# Altium schematic sheet Arduino Connectors.SchDoc("Arduino Connectors")
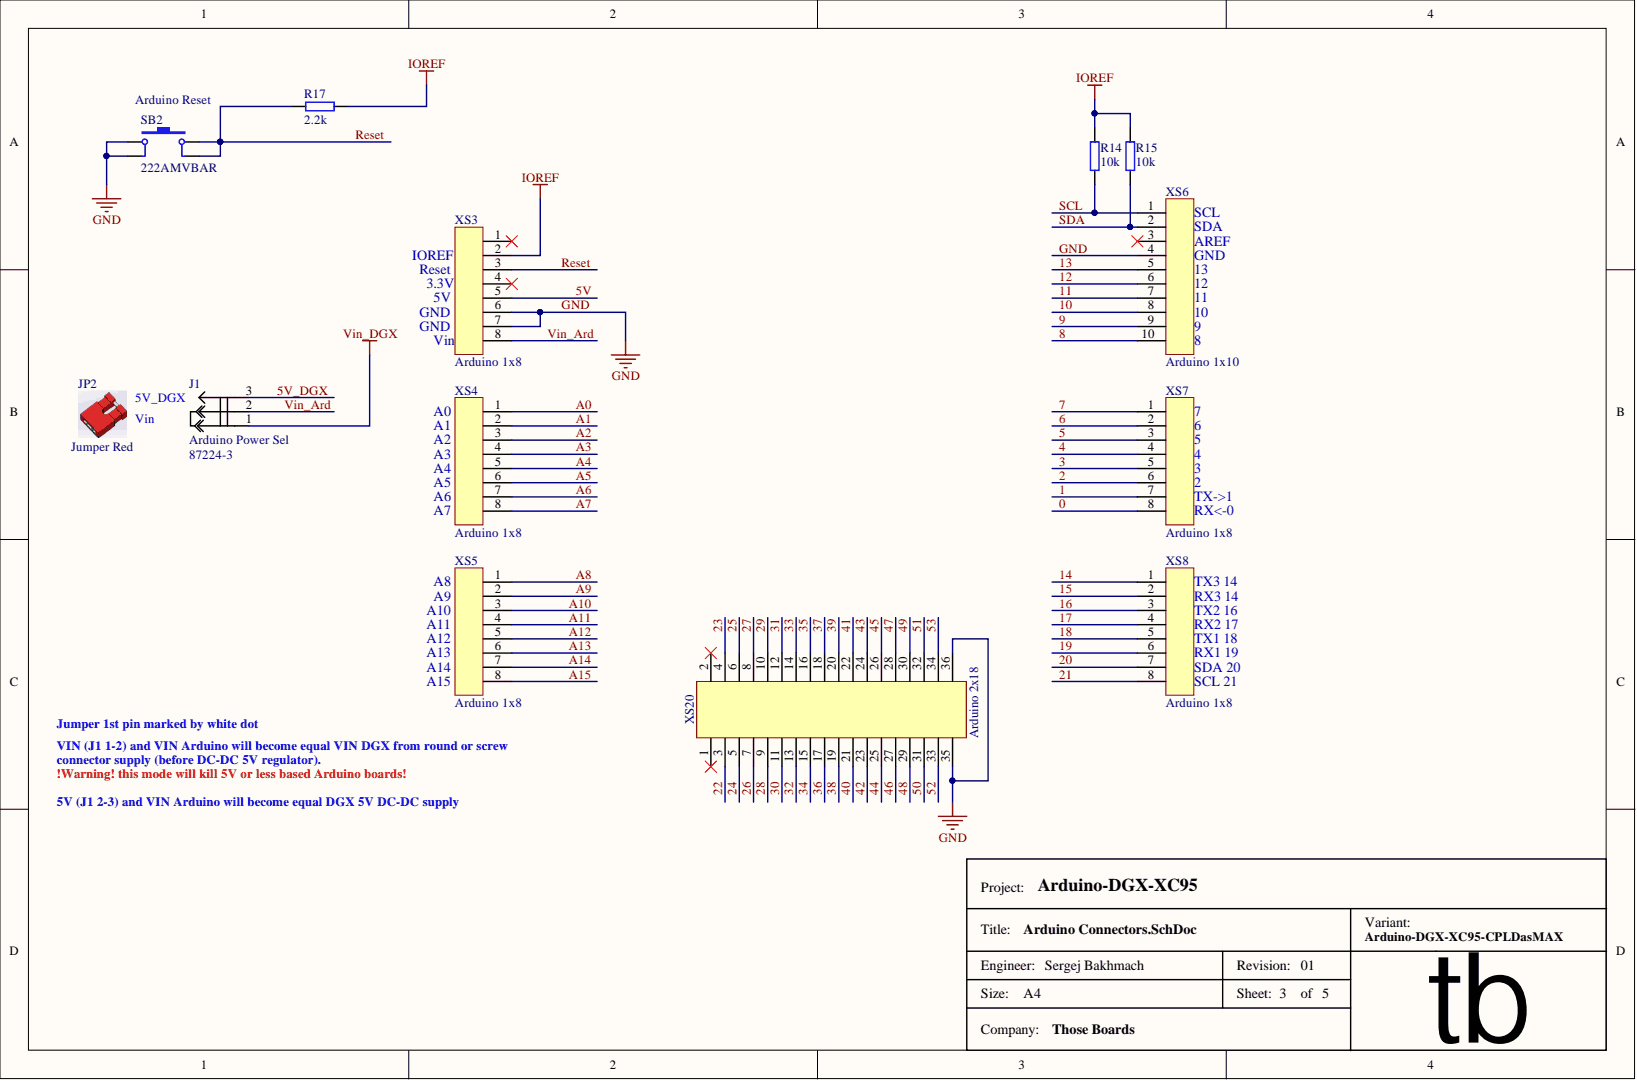
[diagram p0: Arduino Connectors.SchDoc]

## Parts
J1  Arduino Power Sel  value 87224-3  Manufacturer Part: 87224-3  [Jumper_3pin_red]
JP2  value Jumper Red  Supplier Part: Jumper  (hidden comment: VCC_sel)  (mechanical)
R14  value 10k  [0603_RESISTOR]  (hidden comment: Resistor)
R15  value 10k  [0603_RESISTOR]  (hidden comment: Resistor)
R17  value 2.2k  [0603_RESISTOR]  (hidden comment: Resistor)
SB2  Arduino Reset  value 222AMVBAR  Manufacturer Part: 222AMVBAR  [Key 6.2x6.2]
XS3  value Arduino 1x8  [Conn_Arduino_8p_2.54]  (hidden comment: conn_Arduino_8p)
XS4  value Arduino 1x8  [Conn_Arduino_8p_2.54]  (hidden comment: conn_Arduino_8p)
XS5  value Arduino 1x8  [Conn_Arduino_8p_2.54]  (hidden comment: conn_Arduino_8p)
XS6  value Arduino 1x10  [Conn_Arduino_10p_2.54]  (hidden comment: conn_Arduino_10p)
XS7  value Arduino 1x8  [Conn_Arduino_8p_2.54]  (hidden comment: conn_Arduino_8p)
XS8  value Arduino 1x8  [Conn_Arduino_8p_2.54]  (hidden comment: conn_Arduino_8p)
XS20  value Arduino 2x18  [Conn_Arduino_2x18p_2.54]  (hidden comment: conn_Arduino_2x18p)

## Nets
IOREF: R14.2 R15.2 R17.1 XS3.2
VIN_DGX: J1.1
0: XS7.8
1: XS7.7
2: XS7.6
3: XS7.5
4: XS7.4
5: XS7.3
5V: XS3.5
5V_DGX: J1.3
6: XS7.2
7: XS7.1
8: XS6.10
9: XS6.9
10: XS6.8
11: XS6.7
12: XS6.6
13: XS6.5
14: XS8.1
15: XS8.2
16: XS8.3
17: XS8.4
18: XS8.5
19: XS8.6
20: XS8.7
21: XS8.8
22: XS20.3
23: XS20.4
24: XS20.5
25: XS20.6
26: XS20.7
27: XS20.8
28: XS20.9
29: XS20.10
30: XS20.11
31: XS20.12
32: XS20.13
33: XS20.14
34: XS20.15
35: XS20.16
36: XS20.17
37: XS20.18
38: XS20.19
39: XS20.20
40: XS20.21
41: XS20.22
42: XS20.23
43: XS20.24
44: XS20.25
45: XS20.26
46: XS20.27
47: XS20.28
48: XS20.29
49: XS20.30
50: XS20.31
51: XS20.32
52: XS20.33
53: XS20.34
A0: XS4.1
A1: XS4.2
A2: XS4.3
A3: XS4.4
A4: XS4.5
A5: XS4.6
A6: XS4.7
A7: XS4.8
A8: XS5.1
A9: XS5.2
A10: XS5.3
A11: XS5.4
A12: XS5.5
A13: XS5.6
A14: XS5.7
A15: XS5.8
GND: SB2.1 SB2.3 XS3.6 XS3.7 XS6.4 XS20.35 XS20.36
RESET: R17.2 SB2.2 SB2.4 XS3.3
SCL: R14.1 XS6.1
SDA: R15.1 XS6.2
VIN_ARD: J1.2 XS3.8

Not connected: XS3 1 4; XS6 3; XS20 1 2

## Library data of the parts
J1 = jumper_3pin: Manufacturer: TE Connectivity / AMP; Type of Component: Header 1x3; Component Kind: Standard; Manufacturer Link: http://www.te.com/usa-en/product-87224-3.html#pdp-related-products-inner; Package / Case: Header 1 x 3; Supplier: mouser; Supplier Link: http://www.mouser.ee/ProductDetail/TE-Connectivity-AMP/87224-3/?qs=sGAEpiMZZMs%252bGHln7q6pm48SVpWlpfsErguO%2fp0873w%3d; Supplier Part: 571-872243; Library Name: RPI-VITA.IntLib
JP2 = jumper red: Manufacturer: Great Electric; Manufacturer Part: Jumper Cap Shunts Short Circuit Cap; Package / Case: 2.54mm; Supplier: aliexpress.com; Supplier Link: http://www.aliexpress.com/item/500pcs-lot-Asssorted-Color-2-54mm-Standard-Circuit-Board-Jumper-Cap-Shunts-Short-Circuit-Cap-CGKCH119/32344305619.html?spm=2114.03010208.3.80.nPPmoy&ws_ab_test=searchweb201556_1,searchweb201644_1_505_506_503_504_502_10001_10002_10017_10010_10005_10011_10006_10003_10004_10009_10007_10008,searchweb201560_5,searchweb1451318400_-1,searchweb1451318411_6452&btsid=16d11777-edde-4755-b8b5-9adacb495b09; Type of Component: Jumper Red; Library Name: RPI-VITA.IntLib
R14 R15 = Resistor: Manufacturer: Vishay / Dale; Manufacturer Part: CRCW060310K0FKEA; Component Kind: Standard; Manufacturer Link: http://www.vishay.com/product?docid=20035; Package / Case: 0603; Supplier: mouser; Supplier Link: http://www.mouser.com/ProductDetail/Vishay-Dale/CRCW060310K0FKEA/?qs=sGAEpiMZZMu61qfTUdNhGyptJL5EcUtREGTsEKG7Lak%3d; Supplier Part: 71-CRCW0603-10K-E3; Type of Component: Resistor; Library Name: RPI-VITA.IntLib
R17 = Resistor: Manufacturer: Vishay / Dale; Manufacturer Part: CRCW06032K20JNEA; Component Kind: Standard; Manufacturer Link: http://www.vishay.com/product?docid=20035; Package / Case: 0603; Supplier: mouser; Supplier Link: http://www.mouser.com/ProductDetail/Vishay-Dale/CRCW06032K20JNEA/?qs=sGAEpiMZZMvdGkrng054txEw7b1YnvGuRJLBQI6feSk%3d; Supplier Part: 71-CRCW0603J-2.2K-E3; Type of Component: Resistor; Library Name: RPI-VITA.IntLib
SB2 = SB_Tactile: Tactile Switches; Manufacturer: E-Switch; Type of Component: Tact Switch; Component Kind: Standard; Package / Case: 6.2 x 6.2 x 5; Supplier: mouser; Supplier Link: http://www.mouser.ee/ProductDetail/CTS-Electronic-Components/222AMVBAR/?qs=sGAEpiMZZMsgGjVA3toVBB5GWLGpesfgV6sVMBFzGDw%3d; Supplier Part: 774-222AMVBAR; Library Name: RPI-VITA.IntLib
XS3-XS5 XS7 XS8 = conn_Arduino_8p: PLS-8 2.54mm Pitch, 10.5mm High; Manufacturer: China; Type of Component: Arduino Header 1x8; Component Kind: Standard; Package / Case: Header 8; Supplier: aliexpress.com; Supplier Link: http://ru.aliexpress.com/item/40PCS-x-8-Pin-40x-6-Pin-Stackable-Female-Shield-Header-Tall-Stacking-Kit-Special-long/689203895.html; Library Name: RPI-VITA.IntLib
XS6 = conn_Arduino_10p: PLS-10 2.54mm Pitch, 10.5mm High; Manufacturer: China; Type of Component: Arduino Header 1x10; Component Kind: Standard; Package / Case: Header 10; Supplier: aliexpress.com; Supplier Link: http://ru.aliexpress.com/item/20PCS-2x18-Pin-2-54-mm-Stackable-Long-Legs-Femal-Header-For-Arduino-Shield/1524702262.html; Library Name: RPI-VITA.IntLib
XS20 = conn_Arduino_2x18p: Arduino 2x18 2.54mm Pitch; Manufacturer: Esooho; Type of Component: Arduino Header 2x18; Component Kind: Standard; Supplier: aliexpress.com; Supplier Link: http://ru.aliexpress.com/item/20PCS-2x18-Pin-2-54-mm-Stackable-Long-Legs-Femal-Header-For-Arduino-Shield/1524702262.html; Library Name: RPI-VITA.IntLib
